# Altium schematic sheet 5.04.51805A02_RKGMAC0.SchDoc(5.04.51805A02_RKGMAC0)
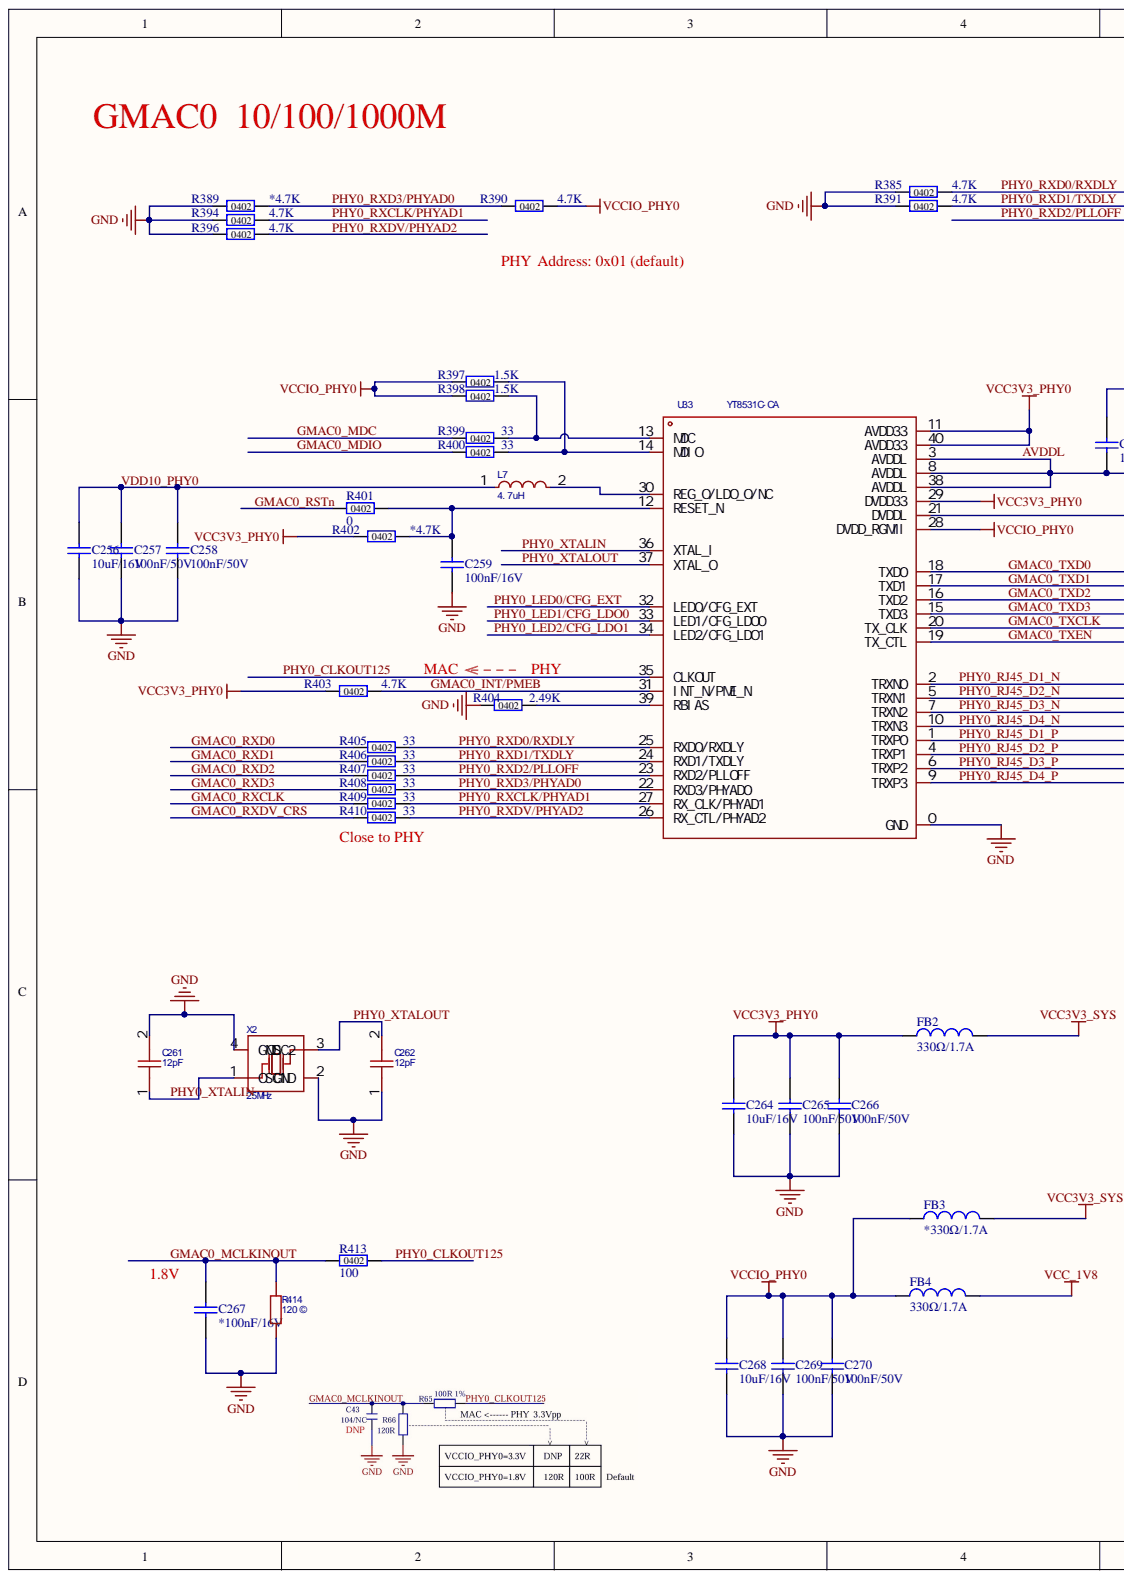
[diagram p0: 5.04.51805A02_RKGMAC0.SchDoc, left]
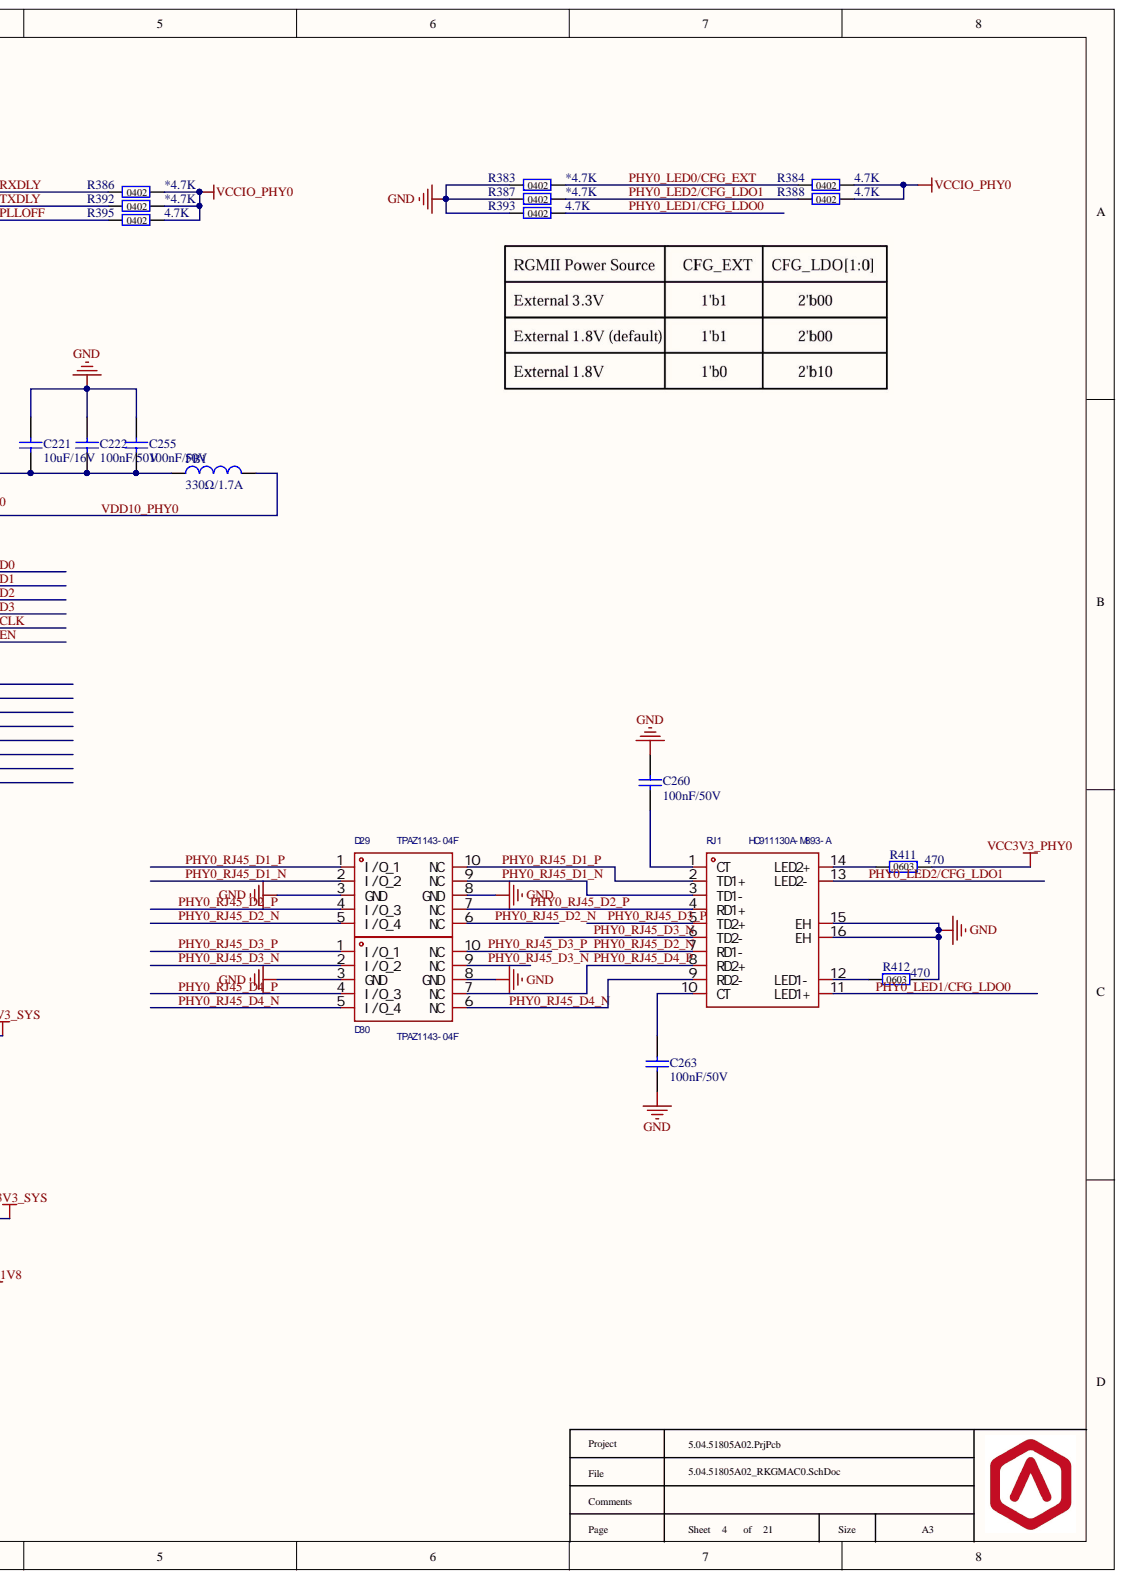
[diagram p1: 5.04.51805A02_RKGMAC0.SchDoc, right]

## Parts
C221  10uF/16V  [C0603]
C222  100nF/50V  [C0402]
C255  100nF/50V  [C0402]
C256  10uF/16V  [C0603]
C257  100nF/50V  [C0402]
C258  100nF/50V  [C0402]
C259  100nF/16V  [C0402]
C260  100nF/50V  [C0402]
C261  12pF  [C0402]
C262  12pF  [C0402]
C263  100nF/50V  [C0402]
C264  10uF/16V  [C0603]
C265  100nF/50V  [C0402]
C266  100nF/50V  [C0402]
C267  *100nF/16V  [C0402]
C268  10uF/16V  [C0603]
C269  100nF/50V  [C0402]
C270  100nF/50V  [C0402]
D29  TPAZ1143-04F  [DFN-10_L2.5-W1.0-P0.50-BL-A]
D30  TPAZ1143-04F  [DFN-10_L2.5-W1.0-P0.50-BL-A]
FB1  330Ω/1.7A  [L0603]
FB2  330Ω/1.7A  [L0603]
FB3  *330Ω/1.7A  [L0603]
FB4  330Ω/1.7A  [L0603]
L7  4.7uH  [IND-SMD_L2.0-W1.6]
R383  *4.7K  [R0402]
R384  4.7K  [R0402]
R385  4.7K  [R0402]
R386  *4.7K  [R0402]
R387  *4.7K  [R0402]
R388  4.7K  [R0402]
R389  *4.7K  [R0402]
R390  4.7K  [R0402]
R391  4.7K  [R0402]
R392  *4.7K  [R0402]
R393  4.7K  [R0402]
R394  4.7K  [R0402]
R395  4.7K  [R0402]
R396  4.7K  [R0402]
R397  1.5K  [R0402]
R398  1.5K  [R0402]
R399  33  [R0402]
R400  33  [R0402]
R401  0  [R0402]
R402  *4.7K  [R0402]
R403  4.7K  [R0402]
R404  2.49K  [R0402]
R405  33  [R0402]
R406  33  [R0402]
R407  33  [R0402]
R408  33  [R0402]
R409  33  [R0402]
R410  33  [R0402]
R411  470  [R0603]
R412  470  [R0603]
R413  100  [R0402]
R414  120Ω  [R0402]
RJ1  HC911130A-M893-A  [RJ45-TH_HY911130AE]
U33  YT8531C-CA  [QFN-40_L5.0-W5.0-P0.40-TL-EP3.7]
X2  25MHz  [CRYSTAL-SMD_4P-L3.2-W2.5-BL]

## Nets
GND: C221.2 C222.2 C255.2 C256.1 C257.1 C258.1 C259.1 C260.2 C261.2 C262.1 C263.1 C264.1 C265.1 C266.1 C267.1 C268.1 C269.1 C270.1 D29.3(GND) D29.8(GND) D30.3(GND) D30.8(GND) R383.1 R385.1 R387.1 R389.1 R391.1 R393.1 R394.1 R396.1 R404.1 R412.2 R414.1 RJ1.15(EH) RJ1.16(EH) U33.0(GND) X2.2(GND) X2.4(GND)
VCC3V3_PHY0: C264.2 C265.2 C266.2 FB2.1 R402.1 R403.1 R411.2 U33.11(AVDD33) U33.29(DVDD33) U33.40(AVDD33)
VCC3V3_SYS: FB2.2 FB3.2
VCC_1V8: FB4.2
VCCIO_PHY0: C268.2 C269.2 C270.2 FB3.1 FB4.1 R384.2 R386.2 R388.2 R390.2 R392.2 R395.2 R397.1 R398.1 U33.28(DVDD_RGMII)
AVDDL: C221.1 C222.1 C255.1 FB1.1 U33.3(AVDDL) U33.8(AVDDL) U33.38(AVDDL)
GMAC0_INT/PMEB: R403.2 U33.31(INT_N/PME_N)
GMAC0_MCLKINOUT: C267.2 R413.1 R414.2
GMAC0_MDC: R399.1
GMAC0_MDIO: R400.1
GMAC0_RSTN: R401.1
GMAC0_RXCLK: R409.1
GMAC0_RXD0: R405.1
GMAC0_RXD1: R406.1
GMAC0_RXD2: R407.1
GMAC0_RXD3: R408.1
GMAC0_RXDV_CRS: R410.1
GMAC0_TXCLK: U33.20(TX_CLK)
GMAC0_TXD0: U33.18(TXD0)
GMAC0_TXD1: U33.17(TXD1)
GMAC0_TXD2: U33.16(TXD2)
GMAC0_TXD3: U33.15(TXD3)
GMAC0_TXEN: U33.19(TX_CTL)
PHY0_CLKOUT125: R413.2 U33.35(CLKOUT)
PHY0_LED0/CFG_EXT: R383.2 R384.1 U33.32(LED0/CFG_EXT)
PHY0_LED1/CFG_LDO0: R393.2 RJ1.11(LED1+) U33.33(LED1/CFG_LDO0)
PHY0_LED2/CFG_LDO1: R387.2 R388.1 RJ1.13(LED2-) U33.34(LED2/CFG_LDO1)
PHY0_RJ45_D1_N: D29.2(I/O_2) D29.9(NC) RJ1.3(TD1-) U33.2(TRXN0)
PHY0_RJ45_D1_P: D29.1(I/O_1) D29.10(NC) RJ1.2(TD1+) U33.1(TRXP0)
PHY0_RJ45_D2_N: D29.5(I/O_4) D29.6(NC) RJ1.7(RD1-) U33.5(TRXN1)
PHY0_RJ45_D2_P: D29.4(I/O_3) D29.7(NC) RJ1.4(RD1+) U33.4(TRXP1)
PHY0_RJ45_D3_N: D30.2(I/O_2) D30.9(NC) RJ1.6(TD2-) U33.7(TRXN2)
PHY0_RJ45_D3_P: D30.1(I/O_1) D30.10(NC) RJ1.5(TD2+) U33.6(TRXP2)
PHY0_RJ45_D4_N: D30.5(I/O_4) D30.6(NC) RJ1.9(RD2-) U33.10(TRXN3)
PHY0_RJ45_D4_P: D30.4(I/O_3) D30.7(NC) RJ1.8(RD2+) U33.9(TRXP3)
PHY0_RXCLK/PHYAD1: R394.2 R409.2 U33.27(RX_CLK/PHYAD1)
PHY0_RXD0/RXDLY: R385.2 R386.1 R405.2 U33.25(RXD0/RXDLY)
PHY0_RXD1/TXDLY: R391.2 R392.1 R406.2 U33.24(RXD1/TXDLY)
PHY0_RXD2/PLLOFF: R395.1 R407.2 U33.23(RXD2/PLLOFF)
PHY0_RXD3/PHYAD0: R389.2 R390.1 R408.2 U33.22(RXD3/PHYAD0)
PHY0_RXDV/PHYAD2: R396.2 R410.2 U33.26(RX_CTL/PHYAD2)
PHY0_XTALIN: C261.1 U33.36(XTAL_I) X2.1(OSC1)
PHY0_XTALOUT: C262.2 U33.37(XTAL_O) X2.3(OSC2)
VDD10_PHY0: C256.2 C257.2 C258.2 FB1.2 L7.1 U33.21(DVDDL)
NetC259_2: C259.2 R401.2 R402.2 U33.12(RESET_N)
NetC260_1: C260.1 RJ1.1(CT)
NetC263_2: C263.2 RJ1.10(CT)
NetL7_2: L7.2 U33.30(REG_O/LDO_O/NC)
NetR397_2: R397.2 R400.2 U33.14(MDIO)
NetR398_2: R398.2 R399.2 U33.13(MDC)
NetR404_2: R404.2 U33.39(RBIAS)
NetR411_1: R411.1 RJ1.14(LED2+)
NetR412_1: R412.1 RJ1.12(LED1-)

## Library data of the parts
C221 C256 C264 C268 = C0603_10uF_16V: Ceramic Capacitor, 10uF, ±10%, 16V, -55°C~85°C, C0603; Manufacturer1: SAMSUNG; ManufacturerPartNumber1: CL10A106KO8NQNC; Code: 5172C106; Component Kind: Standard; Library Name: Raise3D_Capacitor.IntLib
C222 C255 C257 C258 C260 C263 C265 C266 C269 C270 = C0402_100nF_50V: Ceramic Capacitor, 100nF, ±10%, 50V, -55°C~125°C, C0402; Manufacturer1: Yageo; ManufacturerPartNumber1: CC0402KRX7R9BB104; Code: 5191C104; Component Kind: Standard; Manufacturer2: MuRata; ManufacturerPartNumber2: GRM155R71H104KE14D; Library Name: Raise3D_Capacitor.IntLib
C259 C267 = C0402_100nF_16V: Ceramic Capacitor, 100nF, ±10%, 16V, -55°C~125°C, C0402; Manufacturer1: Yageo; ManufacturerPartNumber1: CC0402KPX7R7BB104; Code: 5171C104; Component Kind: Standard; Library Name: Raise3D_Capacitor.IntLib
C261 C262 = GCM1555C1H120FA16D: 12pF ±1% 50V; Manufacturer1: muRata(村田); Component Kind: Standard; ManufacturerPartNumber1: GCM1555C1H120FA16D; PCB3D: C0402_L1.0-W0.5-H0.5; Library Name: QQQ.IntLib
D29 D30 = TPAZ1143-04F: 3.3V，四路，4A，3.6V; Manufacturer1: TECH PUBLIC(台舟); Component Kind: Standard; ManufacturerPartNumber1: TPAZ1143-04F; Library Name: QQQ.IntLib
FB1-FB4 = Bead_330Ohm_1.7A: Bead,MPZ1608S331A,330Ohm,1.7A,25%,L0603,-55°C~125°C; Manufacturer1: TDK; ManufacturerPartNumber1: MPZ1608S331ATA00; Code: 52010002; Component Kind: Standard; Library Name: Raise3D_Inductor&Bead.IntLib
L7 = FHD201610S-4R7MT: 4.7uH ±20% 1.4A; Manufacturer1: cjiang(长江微电); Component Kind: Standard; ManufacturerPartNumber1: FHD201610S-4R7MT; PCB3D: IND-SMD_L2.0-W1.6-H1.1; Library Name: QQQ.IntLib
R383-R396 R402 R403 = R0402_4.7K: Resistor 4.7Kohm,5%,1/16W,R0402; Manufacturer1: Yageo; ManufacturerPartNumber1: RC0402JR-074K7L; Code: 501104K7; Component Kind: Standard; Manufacturer2: Samsung; ManufacturerPartNumber2: RCS1005J472CS; Library Name: Raise3D_Resistor.IntLib
R397 R398 = R0402_1.5K: Resistor 1.5Kohm,5%,1/16W,R0402; Manufacturer1: Samsung; ManufacturerPartNumber1: RC1005J152CS; Code: 501101K5; Component Kind: Standard; Library Name: Raise3D_Resistor.IntLib
R399 R400 R405-R410 = R0402_33: Resistor 33ohm,1%,1/16W,R0402; Manufacturer1: Yageo; ManufacturerPartNumber1: RC0402FR-0733RL; Code: 5001033R; Component Kind: Standard; Library Name: Raise3D_Resistor.IntLib
R401 = R0402_0: Resistor 0ohm,1%,1/16W,R0402; Manufacturer1: Yageo; ManufacturerPartNumber1: RC0402FR-070RL; Code: 5001000R; Component Kind: Standard; Library Name: Raise3D_Resistor.IntLib
R404 = R0402_2.49K: Resistor  2.49Kohm,1%,1/16W,R0402; Manufacturer1: Yageo; ManufacturerPartNumber1: RC0402FR-072K49L; code: 50012K49; Component Kind: Standard; Library Name: Raise3D_Resistor.IntLib
R411 R412 = R0603_470: Resistor 470ohm,1%,1/10W,R0603; Manufacturer1: Yageo; ManufacturerPartNumber1: RC0603FR-07470RL; Code: 5002470R; Component Kind: Standard; Library Name: Raise3D_Resistor.IntLib
R413 = R0402_100: Resistor 100ohm,1%,1/16W,R0402; Manufacturer1: Yageo; ManufacturerPartNumber1: RC0402FR-07100RL; Code: 5001100R; Component Kind: Standard; Manufacturer2: Samsung; ManufacturerPartNumber2: RC1005F101CS; Library Name: Raise3D_Resistor.IntLib
R414 = RC0402FR-07120RL: 厚膜电阻 120Ω ±1% 62.5mW; Manufacturer1: YAGEO(国巨); Component Kind: Standard; ManufacturerPartNumber1: RC0402FR-07120RL; Library Name: QQQ.IntLib
RJ1 = HC911130A-M893-A: 弯插 带LED，千兆; Manufacturer1: HCTL(华灿天禄); Component Kind: Standard; ManufacturerPartNumber1: HC911130A-M893-A; PCB3D: RJ45-TH_HY911130AE; Library Name: QQQ.IntLib
U33 = YT8531C-CA: 网络收发器; Manufacturer1: Motorcomm(裕太微); Component Kind: Standard; ManufacturerPartNumber1: YT8531C-CA; Library Name: QQQ.IntLib
X2 = X322525MOB4SI: 25MHz ±10ppm 12pF，-40℃~+85℃; Manufacturer1: YXC(扬兴晶振); Component Kind: Standard; ManufacturerPartNumber1: X322525MOB4SI; PCB3D: OSC-SMD_4P-L3.2-W2.5-H1.0; Library Name: QQQ.IntLib
